# Altium schematic sheet AS5047.SchDoc
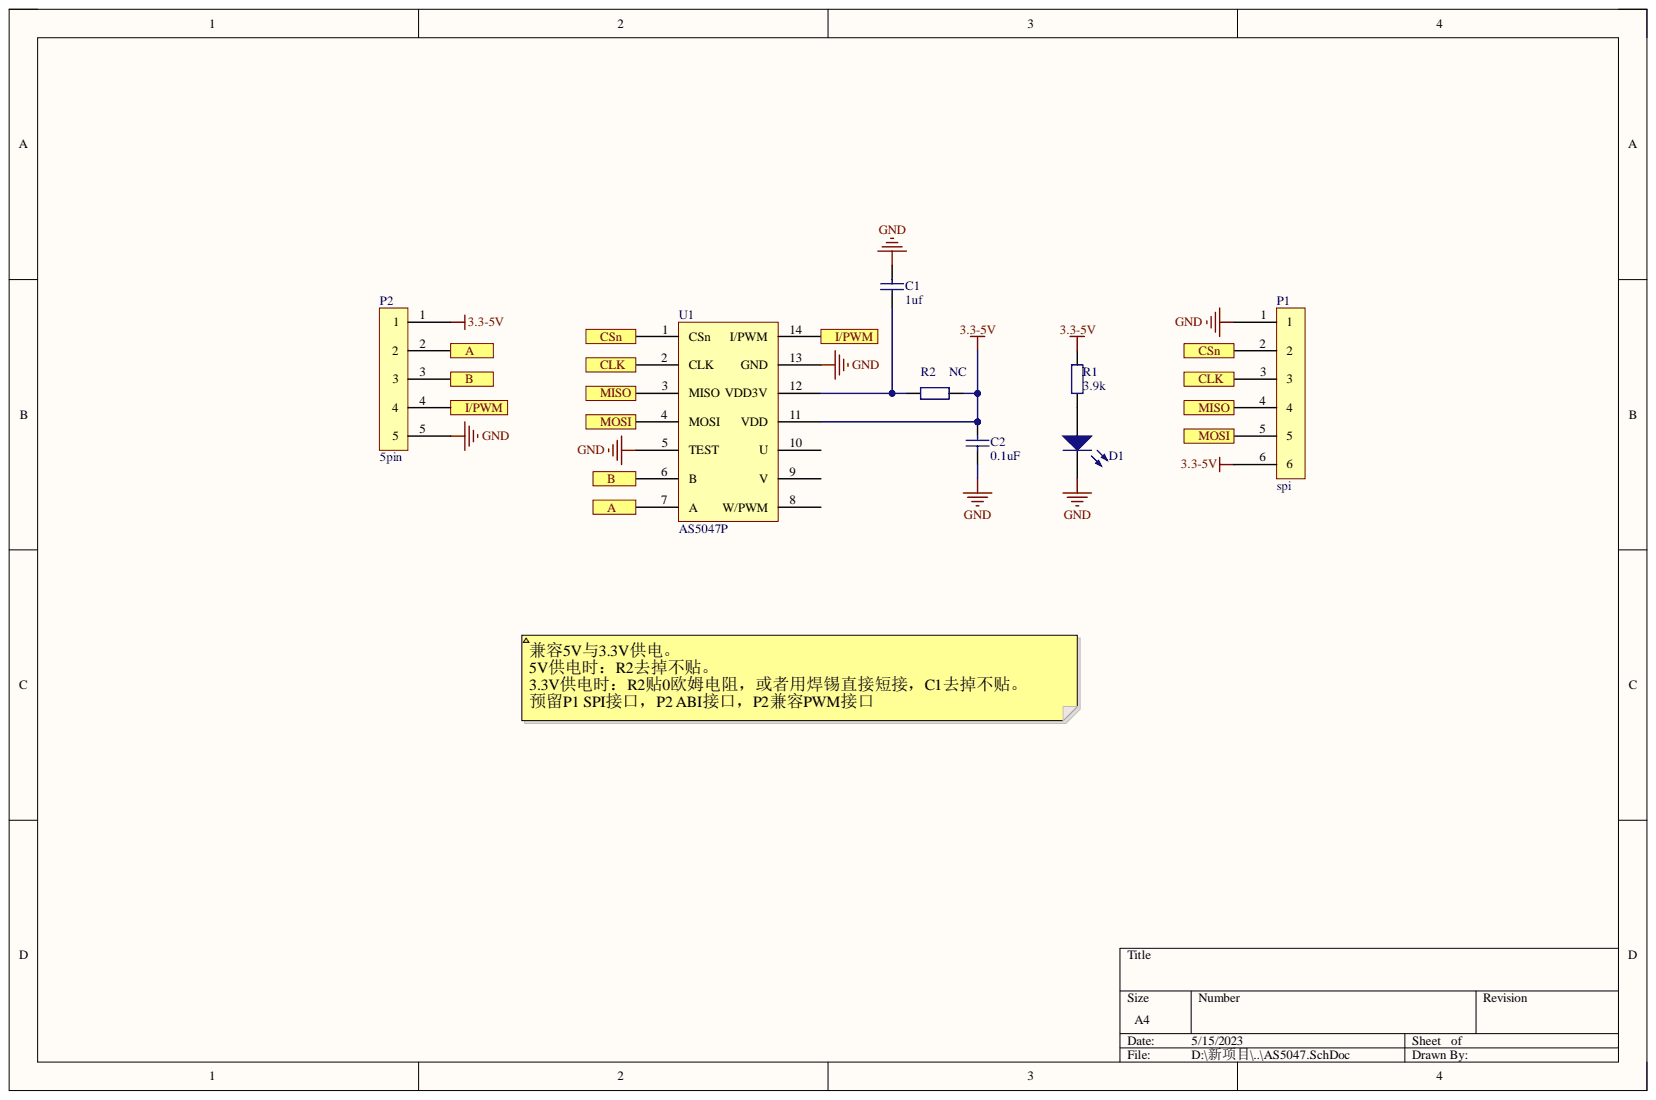
[diagram p0: AS5047.SchDoc]

## Parts
C1  value 1uf  [0805-2]  (hidden comment: Cap)
C2  value 0.1uF  [0805-2]  (hidden comment: Cap)
D1  LED0  [LED_0805]  (value not drawn)
P1  spi  [XH2.54-WS-6P]
P2  5pin  [XH2.54-WS-5P]
R1  value 3.9k  [0805-1]  (hidden comment: Res)
R2  value NC  [0603-1]  (hidden comment: Res)
U1  AS5047P  [TSSOP14_N]

## Ports
A, B, CLK, CSn, I/PWM, MISO, MOSI

## Nets
3.3-5V: C2.1 P1.6 P2.1 R1.2 R2.2 U1.11(VDD)
GND: C1.2 C2.2 D1.2 P1.1 P2.5 U1.5(TEST) U1.13(GND)
A: P2.2 U1.7(A) ; port A
B: P2.3 U1.6(B) ; port B
CLK: P1.3 U1.2(CLK) ; port CLK
CSn: P1.2 U1.1(CSn) ; port CSn
I/PWM: P2.4 U1.14(I/PWM) ; port I/PWM
MISO: P1.4 U1.3(MISO) ; port MISO
MOSI: P1.5 U1.4(MOSI) ; port MOSI
NetC1_1: C1.1 R2.1 U1.12(VDD3V)
NetD1_1: D1.1 R1.1

Not connected: U1 8(W/PWM) 9(V) 10(U)

## Library data of the parts
C1 C2 = Cap: 无极性电容; Component Kind: Standard; LatestRevisionDate: 17-Jul-2002; LatestRevisionNote: Re-released for DXP Platform.; PackageReference: RAD-0.3; Published: 8-Jun-2000; Library Name: Fszhang.IntLib; Publisher: Altium Limited
D1 = LED0: 发光二极管; Component Kind: Standard; LatestRevisionDate: 08-Jul-2005; LatestRevisionNote: Mech Layer 1 removed.; PackageReference: LED-0; Published: 8-Jun-2000; Library Name: Fszhang.IntLib; Publisher: Altium Limited
P1 = 6Pin: Component Kind: Standard; Library Name: JieChaJian.IntLib
R1 R2 = Res: 贴片电阻; Component Kind: Standard; LatestRevisionDate: 17-Jul-2002; LatestRevisionNote: Re-released for DXP Platform.; PackageReference: AXIAL-0.4; Published: 8-Jun-2000; Library Name: Fszhang.IntLib; Publisher: Altium Limited
P2 = 5pin: Component Kind: Standard; Library Name: JieChaJian.IntLib
U1 = AS5047P: Component Kind: Standard; Library Name: FOC.IntLib
